# Altium schematic sheet Sheet3.SchDoc(Sheet3)
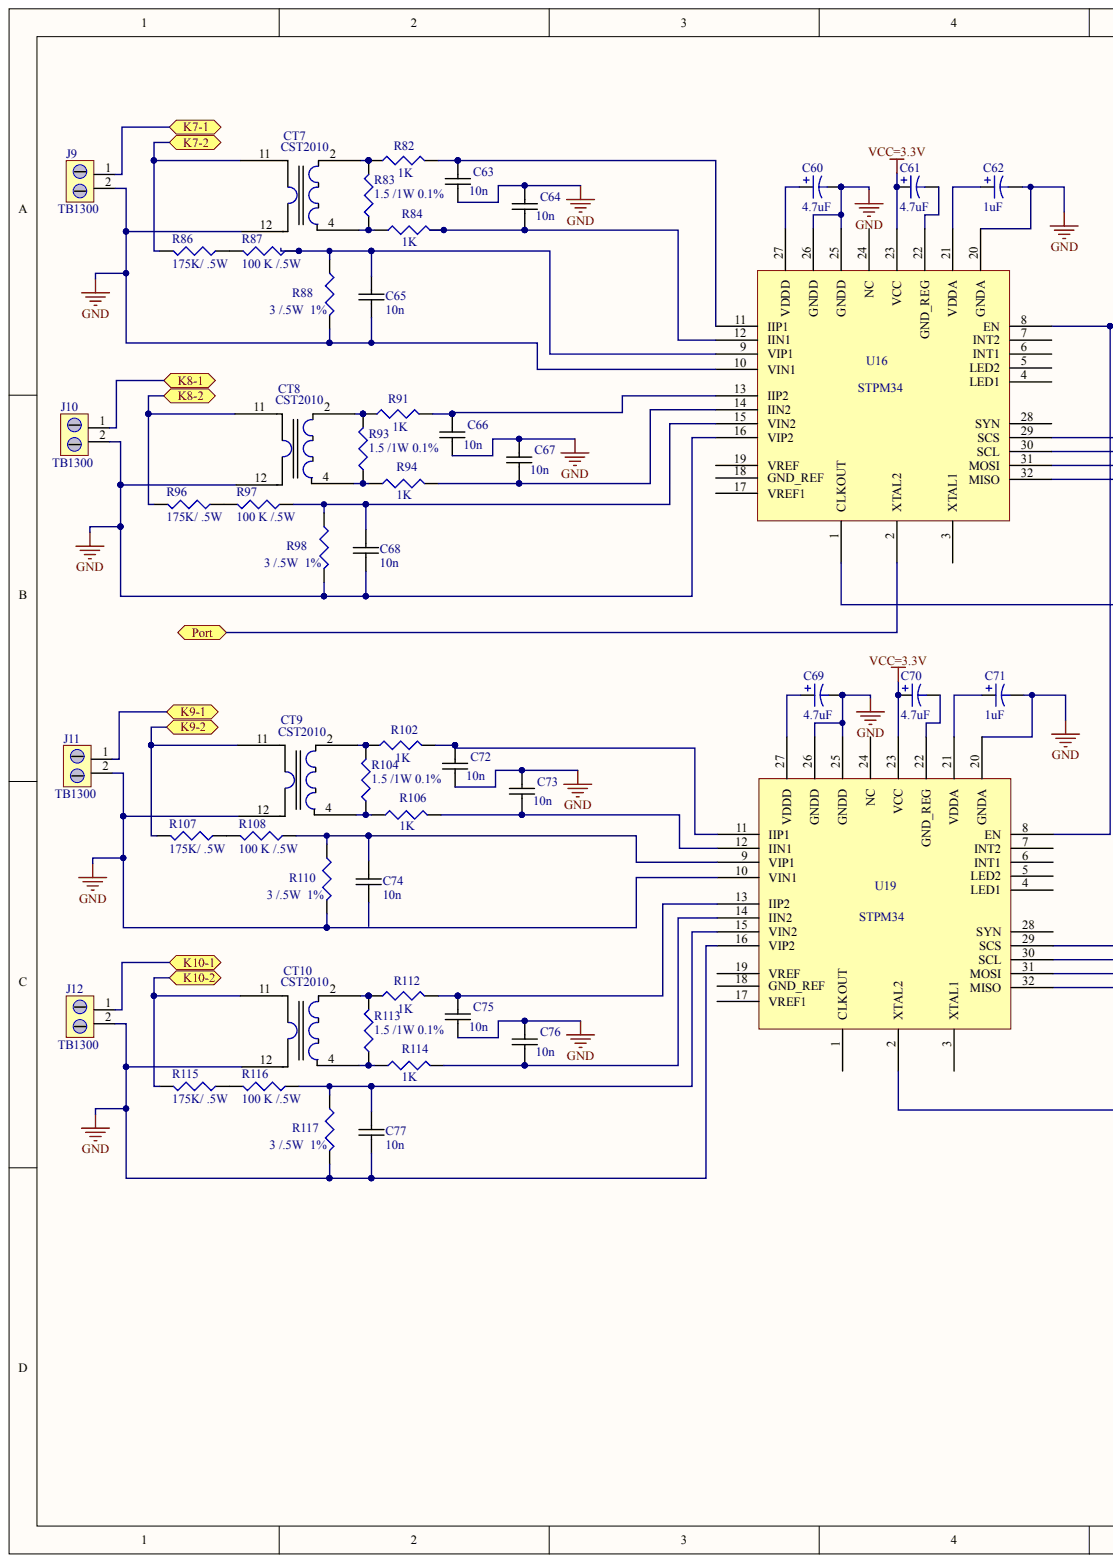
[diagram p0: Sheet3.SchDoc, left]
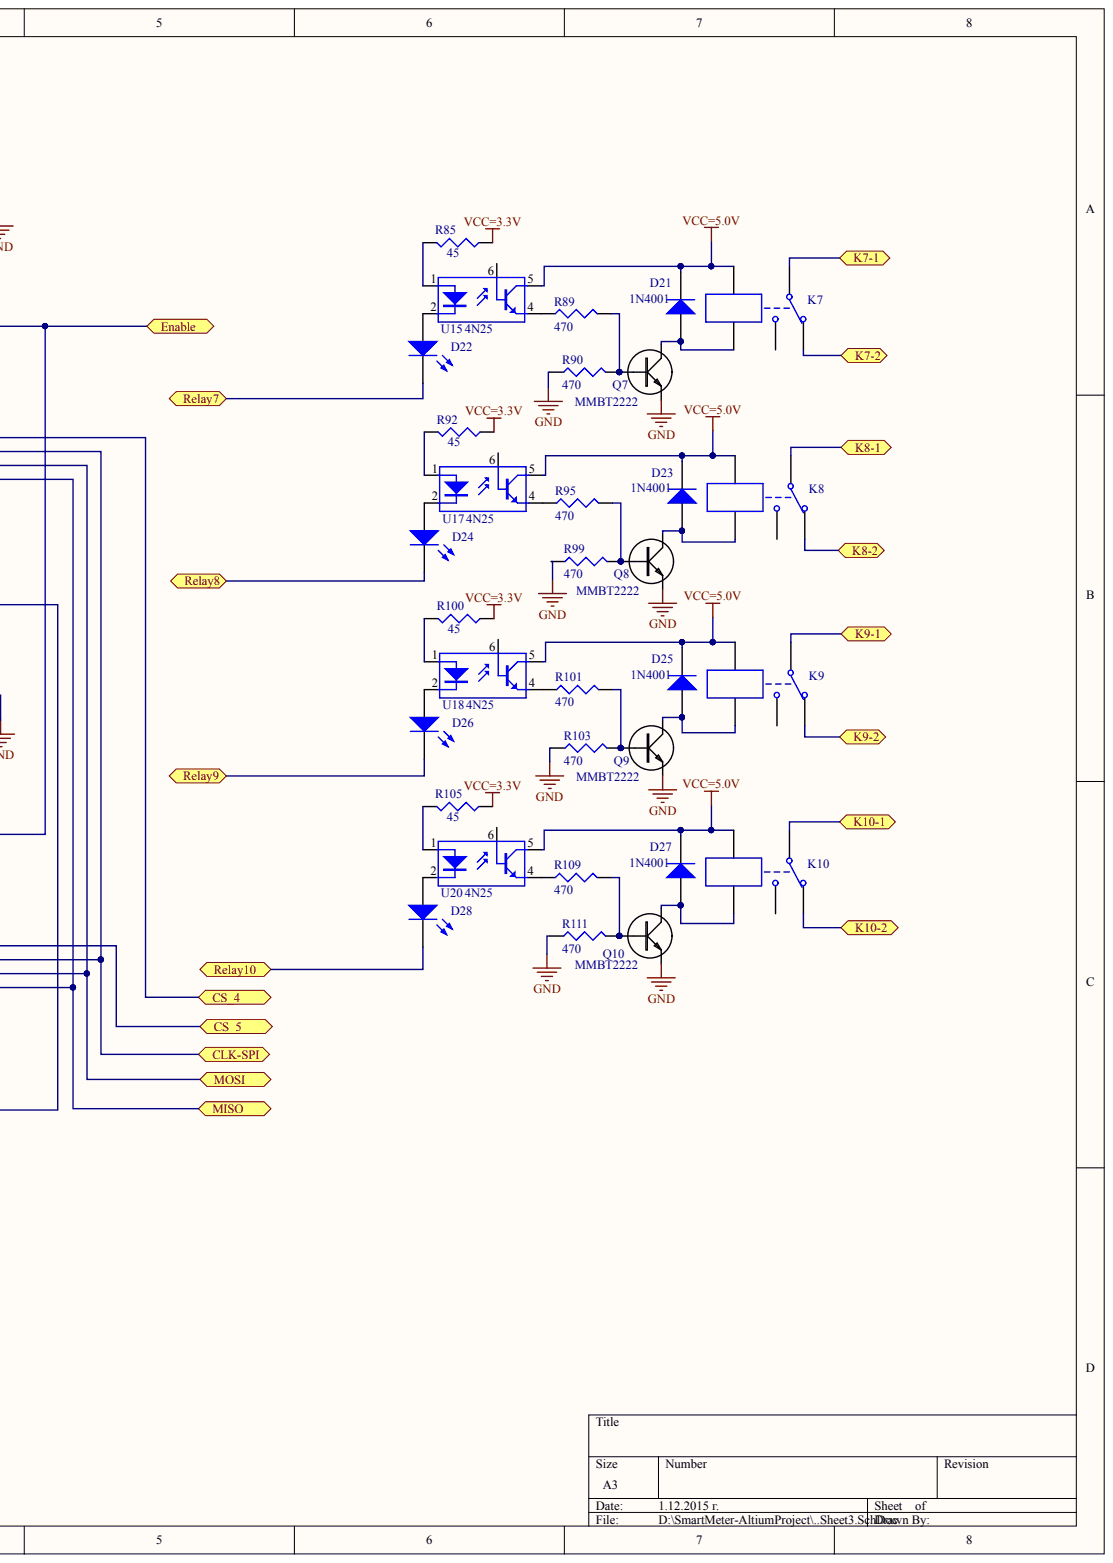
[diagram p1: Sheet3.SchDoc, right]

## Parts
C60  4.7uF
C61  4.7uF
C62  1uF
C63  10n
C64  10n
C65  10n
C66  10n
C67  10n
C68  10n
C69  4.7uF
C70  4.7uF
C71  1uF
C72  10n
C73  10n
C74  10n
C75  10n
C76  10n
C77  10n
CT7  CST2010
CT8  CST2010
CT9  CST2010
CT10  CST2010
D21  1N4001
D22  LED2  (value not drawn)
D23  1N4001
D24  LED2  (value not drawn)
D25  1N4001
D26  LED2  (value not drawn)
D27  1N4001
D28  LED2  (value not drawn)
J9  TB1300
J10  TB1300
J11  TB1300
J12  TB1300
K7  Relay-SPDT  (value not drawn)
K8  Relay-SPDT  (value not drawn)
K9  Relay-SPDT  (value not drawn)
K10  Relay-SPDT  (value not drawn)
Q7  MMBT2222
Q8  MMBT2222
Q9  MMBT2222
Q10  MMBT2222
R82  1K
R83  1.5 /1W 0.1%
R84  1K
R85  45
R86  175K/ .5W
R87  100 K /.5W
R88  3 /.5W  1%
R89  470
R90  470
R91  1K
R92  45
R93  1.5 /1W 0.1%
R94  1K
R95  470
R96  175K/ .5W
R97  100 K /.5W
R98  3 /.5W  1%
R99  470
R100  45
R101  470
R102  1K
R103  470
R104  1.5 /1W 0.1%
R105  45
R106  1K
R107  175K/ .5W
R108  100 K /.5W
R109  470
R110  3 /.5W  1%
R111  470
R112  1K
R113  1.5 /1W 0.1%
R114  1K
R115  175K/ .5W
R116  100 K /.5W
R117  3 /.5W  1%
U15  4N25
U16  STPM34
U17  4N25
U18  4N25
U19  STPM34
U20  4N25

## Ports
CLK-SPI, CS_4, CS_5, ENABLE, K7-1, K7-2, K8-1, K8-2, K9-1, K9-2, K10-1, K10-2, MISO, MOSI, PORT, RELAY7, RELAY8, RELAY9, RELAY10

## Nets
GND: C60.2 C62.2 C63.1 C64.2 C65.1 C66.1 C67.2 C68.1 C69.2 C71.2 C72.1 C73.2 C75.1 C76.2 C77.1 CT7.12 CT8.12 CT9.12 CT10.12 J9.2 J10.2 J11.2 J12.2 Q7.E Q8.E Q9.E Q10.E R88.2 R90.1 R99.1 R103.1 R110.2 R111.1 R117.2 U16.10(VIN1) U16.16(VIP2) U16.20(GNDA) U16.25(GNDD) U16.26(GNDD) U19.10(VIN1) U19.16(VIP2) U19.20(GNDA) U19.25(GNDD) U19.26(GNDD)
VCC=3.3V: C61.1 C70.1 R85.1 R92.1 R100.1 R105.1 U16.23(VCC) U19.23(VCC)
VCC=5.0V: D21.2 D23.2 D25.2 D27.2 K7.4 K8.4 K9.4 K10.4 U15.5 U17.5 U18.5 U20.5
CLK-SPI: U16.30(SCL) U19.30(SCL) ; port CLK-SPI
CS_4: U16.29(SCS) ; port CS_4
CS_5: U19.29(SCS) ; port CS_5
K7-1: J9.1 K7.1 ; port K7-1
K7-2: CT7.11 K7.2 R86.2 ; port K7-2
K8-1: J10.1 K8.1 ; port K8-1
K8-2: CT8.11 K8.2 R96.2 ; port K8-2
K9-1: J11.1 K9.1 ; port K9-1
K9-2: CT9.11 K9.2 R107.2 ; port K9-2
K10-1: J12.1 K10.1 ; port K10-1
K10-2: CT10.11 K10.2 R115.2 ; port K10-2
MISO: U16.32(MISO) U19.32(MISO) ; port MISO
MOSI: U16.31(MOSI) U19.31(MOSI) ; port MOSI
NetC60_1: C60.1 U16.27(VDDD)
NetC61_2: C61.2 U16.22(GND_REG)
NetC62_1: C62.1 U16.21(VDDA)
NetC63_2: C63.2 R82.2 U16.11(IIP1)
NetC64_1: C64.1 R84.2 U16.12(IIN1)
NetC65_2: C65.2 R87.1 R88.1 U16.9(VIP1)
NetC66_2: C66.2 R91.2 U16.13(IIP2)
NetC67_1: C67.1 R94.2 U16.14(IIN2)
NetC68_2: C68.2 R97.1 R98.1 U16.15(VIN2)
NetC69_1: C69.1 U19.27(VDDD)
NetC70_2: C70.2 U19.22(GND_REG)
NetC71_1: C71.1 U19.21(VDDA)
NetC72_2: C72.2 R102.2 U19.11(IIP1)
NetC73_1: C73.1 R106.2 U19.12(IIN1)
NetC74_2: C74.2 R108.1 R110.1 U19.9(VIP1)
NetC75_2: C75.2 R112.2 U19.13(IIP2)
NetC76_1: C76.1 R114.2 U19.14(IIN2)
NetC77_2: C77.2 R116.1 R117.1 U19.15(VIN2)
NetCT7_2: CT7.2 R82.1 R83.2
NetCT7_4: CT7.4 R83.1 R84.1
NetCT8_2: CT8.2 R91.1 R93.2
NetCT8_4: CT8.4 R93.1 R94.1
NetCT9_2: CT9.2 R102.1 R104.2
NetCT9_4: CT9.4 R104.1 R106.1
NetCT10_2: CT10.2 R112.1 R113.2
NetCT10_4: CT10.4 R113.1 R114.1
NetD21_1: D21.1 K7.5 Q7.C
NetD22_1: D22.1 U15.2
NetD22_2: D22.2 ; port RELAY7
NetD23_1: D23.1 K8.5 Q8.C
NetD24_1: D24.1 U17.2
NetD24_2: D24.2 ; port RELAY8
NetD25_1: D25.1 K9.5 Q9.C
NetD26_1: D26.1 U18.2
NetD26_2: D26.2 ; port RELAY9
NetD27_1: D27.1 K10.5 Q10.C
NetD28_1: D28.1 U20.2
NetD28_2: D28.2 ; port RELAY10
NetQ7_B: Q7.B R89.2 R90.2
NetQ8_B: Q8.B R95.2 R99.2
NetQ9_B: Q9.B R101.2 R103.2
NetQ10_B: Q10.B R109.2 R111.2
NetR85_2: R85.2 U15.1
NetR86_1: R86.1 R87.2
NetR89_1: R89.1 U15.4
NetR92_2: R92.2 U17.1
NetR95_1: R95.1 U17.4
NetR96_1: R96.1 R97.2
NetR100_2: R100.2 U18.1
NetR101_1: R101.1 U18.4
NetR105_2: R105.2 U20.1
NetR107_1: R107.1 R108.2
NetR109_1: R109.1 U20.4
NetR115_1: R115.1 R116.2
NetU16_1: U16.1(CLKOUT) U19.2(XTAL2)
NetU16_2: U16.2(XTAL2) ; port PORT
NetU16_8: U16.8(EN) U19.8(EN) ; port ENABLE

Not connected: C74 1; K7 3; K8 3; K9 3; K10 3; R98 2; U15 6; U16 3(XTAL1) 4(LED1) 5(LED2) 6(INT1) 7(INT2) 17(VREF1) 18(GND_REF) 19(VREF) 24(NC) 28(SYN); U17 6; U18 6; U19 1(CLKOUT) 3(XTAL1) 4(LED1) 5(LED2) 6(INT1) 7(INT2) 17(VREF1) 18(GND_REF) 19(VREF) 24(NC) 28(SYN); U20 6

## Library data of the parts
C60-C62 C69-C71 = (no symbol name): Value: 100pF; Published: 8-Jun-2000; LatestRevisionDate: 29-May-2009; LatestRevisionNote: IPC-7351 Footprint Added.; PackageReference: C0805; PackageDescription: Chip Capacitor; Publisher: Altium Limited
R82-R84 R91 R93 R94 R102 R104 R106 R112-R114 = (no symbol name): Value: 1K 1%; Published: 8-Jun-2000; LatestRevisionDate: 29-May-2009; LatestRevisionNote: IPC-7351 Footprint Added.; PackageReference: J1-0603; PackageDescription: Chip Resistor; Publisher: Altium Limited
  R84 R94 R106 R114: manufacture P/N: *
R85 R92 R100 R105 = (no symbol name): Value: 45/.125/1%; Published: 8-Jun-2000; LatestRevisionDate: 29-May-2009; LatestRevisionNote: IPC-7351 Footprint Added.; PackageReference: J1-0603; PackageDescription: Chip Resistor; mfr PN: *; Publisher: Altium Limited
R86 R96 R107 R115 = (no symbol name): Value: 175k/ .5 W 1%; Published: 8-Jun-2000; LatestRevisionDate: 29-May-2009; LatestRevisionNote: IPC-7351 Footprint Added.; PackageReference: J1-0603; PackageDescription: Chip Resistor; mfr PN: *; Package Reference: 1206; Publisher: Altium Limited
R87 R97 R108 R116 = (no symbol name): Value: 100k /.5 W 1%; Published: 8-Jun-2000; LatestRevisionDate: 29-May-2009; LatestRevisionNote: IPC-7351 Footprint Added.; PackageReference: J1-0603; PackageDescription: Chip Resistor; mfr PN: *; Package Reference: 1206; Publisher: Altium Limited
R88 R98 R110 R117 = (no symbol name): Value: 3 .125W 1%; Published: 8-Jun-2000; LatestRevisionDate: 29-May-2009; LatestRevisionNote: IPC-7351 Footprint Added.; PackageReference: J1-0603; PackageDescription: Chip Resistor; Publisher: Altium Limited
R89 R90 R95 R99 R101 R103 R109 R111 = (no symbol name): Value: 470/.125W/1%; Published: 8-Jun-2000; LatestRevisionDate: 29-May-2009; LatestRevisionNote: IPC-7351 Footprint Added.; PackageReference: J1-0603; PackageDescription: Chip Resistor; Publisher: Altium Limited
C63-C68 C72-C77 CT7-CT10 D21-D28 J9-J12 K7-K10 Q7-Q10 U15-U20 = (no symbol name)
  C63 C64 C66 C67 C72 C73 C75 C76: Supplier 1: Digi-Key; Supplier Part Number 1: 709-1171-2-ND; Value: 10n; mfr PN: *; Package reference: 0805-TBD
  C65 C68 C74 C77: Supplier 1: Digi-Key; Supplier Part Number 1: 709-1171-2-ND; Value: 10n; mfr PN: *; Package Reference: 0805-TBD
  D21 D23 D25 D27: Published: 8-Jun-2000; LatestRevisionDate: 17-Jul-2002; LatestRevisionNote: Re-released for DXP Platform.; DatasheetVersion: 1998; PackageVersion: Aug-1999; PackageReference: DO-41; PackageDescription: Diode, Axial; Body 5.2 x 2.7 mm (LxDia. max), Lead Dia. 0.86 mm (max); Code_JEDEC: DO-204-AL; ComponentLink1Description: Manufacturer Link; ComponentLink1URL: http://www.fairchildsemi.com/; ComponentLink2Description: Datasheet; ComponentLink2URL: http://www.fairchildsemi.com/ds/1N%2F1N4001.pdf
  D22 D24 D26 D28: Published: 8-Jun-2000; LatestRevisionDate: 17-Jul-2002; LatestRevisionNote: Re-released for DXP Platform.; PackageVersion: Feb-2002; PackageReference: 3.2X1.6X1.1; PackageDescription: SM LED; 2 Flat Leads
  K7-K10: Published: 24-Mar-1999; LatestRevisionDate: 17-Jul-2002; LatestRevisionNote: Re-released for DXP Platform.; PackageReference: MODULE5B
  Q7-Q10: Supplier 1: Digi-Key; Supplier Part Number 1: MMBT2222A-FDICT-ND
  U15 U17 U18 U20: Published: 24-Mar-1999; LatestRevisionDate: 04-Mar-2005; LatestRevisionNote: PCB Footprint replaced, Sch pins re-numbered.; DatasheetVersion: Apr-2004; PackageReference: SOP5(6); PackageDescription: Small Outline; 6 Leads (1 Absent); Body Width 4.4 mm; Pitch 1.27 mm
  D21-D28 K7-K10 U15 U17 U18 U20: Publisher: Altium Limited
